# Altium schematic sheet Э3("All Documents",Physical)
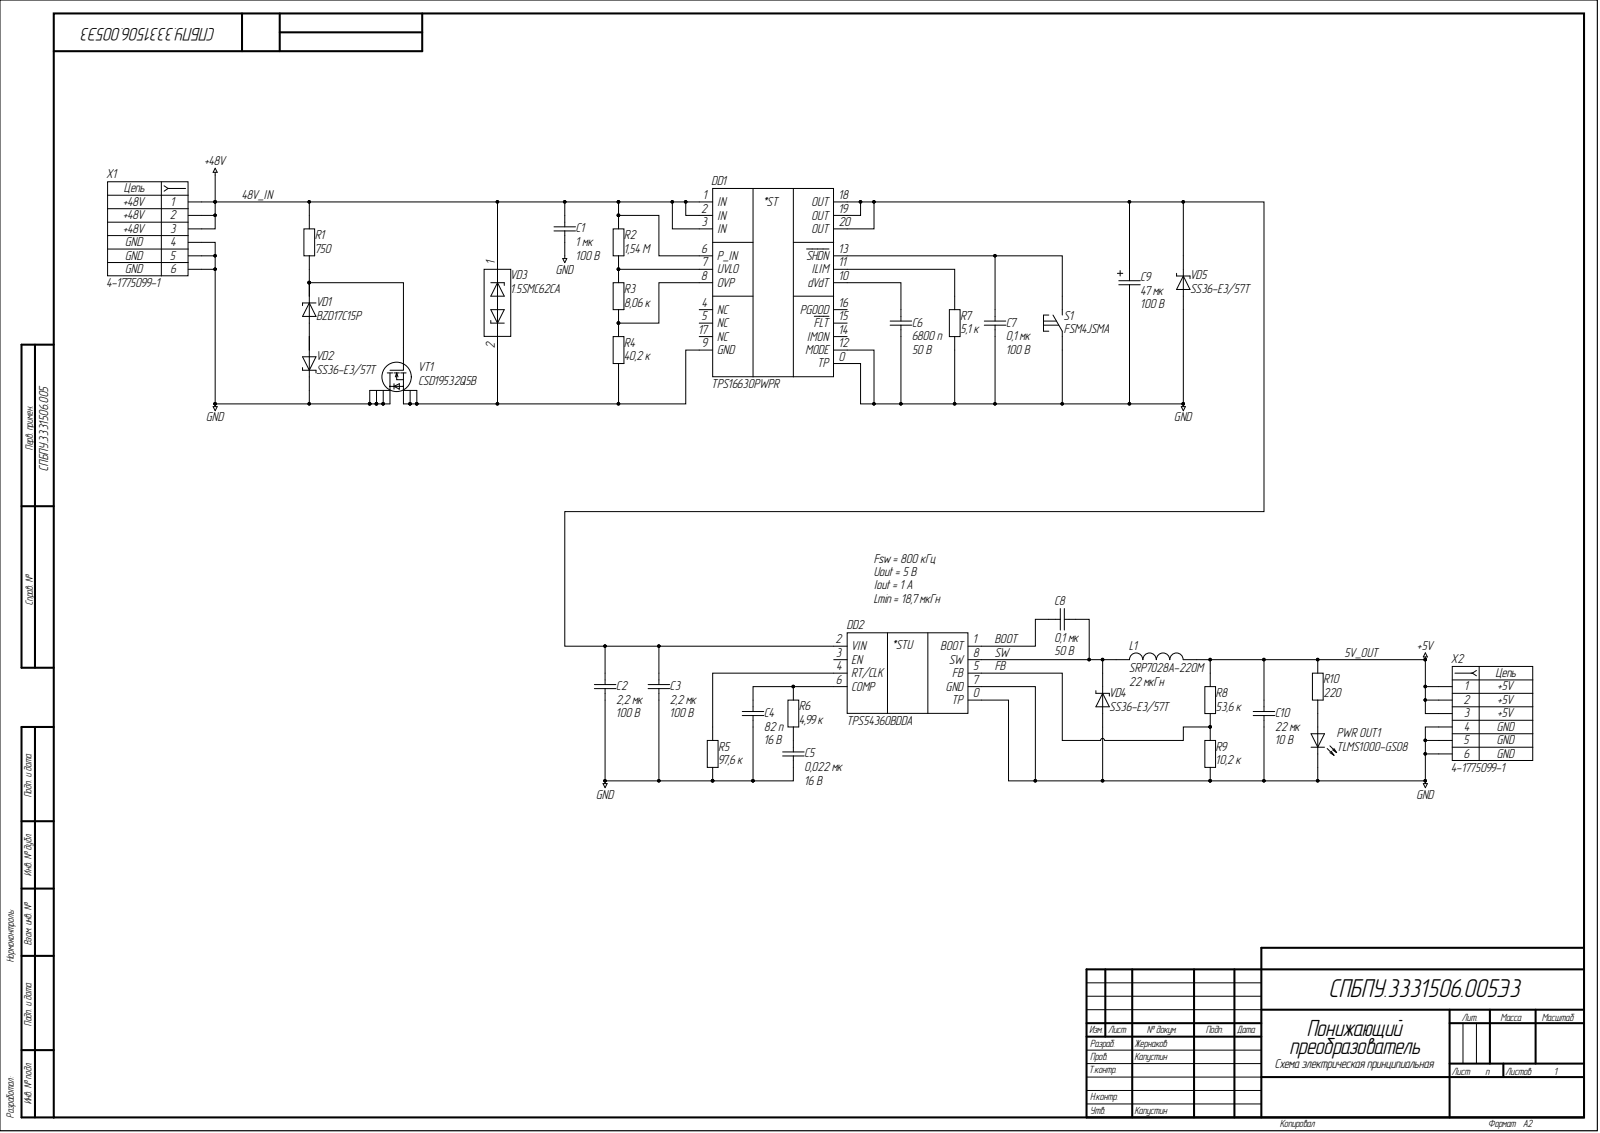
[diagram p0: Э3("All Documents",Physical)]

## Parts
C1  1 мк  [C1206]
C2  2,2 мк  [C1206]
C3  2,2 мк  Номинальное напряжение: 100 В  [C1206]
C4  82 п  [C0603]
C5  0,022 мк  Номинальное напряжение: 16 В  [C0603]
C6  6800 п  Номинальное напряжение: 50 В  [C0402]
C7  0,1 мк  [C0603]
C8  0,1 мк  Номинальное напряжение: 50 В  [C0603]
C9  47 мк  [CAPAE-1050X1050X1250]
C10  22 мк  Номинальное напряжение: 10 В  [C0603]
DD1  TPS16630PWPR  [HTSSOP-20]
DD2  TPS54360BDDA  [HSOIC-8]
L1  SRP7028A-220M  [SRP-2-670X660X280]
PWR_OUT1  TLMS1000-GS08  [HL0603]
R1  750  [R0603]
R2  1,54 М  [R0603]
R3  8,06 к  [R0603]
R4  40,2 к  [R0603]
R5  97,6 к  [R0603]
R6  4,99 к  [R0603]
R7  5,1 к  [R0603]
R8  53,6 к  [R0603]
R9  10,2 к  [R0603]
R10  220  [R0603]
S1  FSM4JSMA  [FSM4JSMA]
VD1  BZD17C15P  [DO-219AB]
VD2  SS36-E3/57T  [DO-214AB]
VD3  1.5SMC62CA  [DO-214AB]
VD4  SS36-E3/57T  [DO-214AB]
VD5  SS36-E3/57T  [DO-214AB]
VT1  CSD19532Q5B  [SON-8]
X1  4-1775099-1  Contact_6: GND  Contact_3: +48V  [4-1775099-1]
X2  4-1775099-1  Contact_6: GND  Contact_3: +5V  [4-1775099-1]

## Nets
GND: C1.2 C2.2 C3.2 C4.2 C5.2 C6.2 C7.2 C9.2 C10.2 DD1.0(TP) DD1.12(MODE) DD2.0(TP) DD2.7(GND) PWR_OUT1.1 R5.1 R7.2 R9.1 S1.1 VD2.1 VD4.2 VD5.2 VT1.D X1.4 X1.5 X1.6 X2.4 X2.5 X2.6
5V_OUT: C10.1 L1.2 R8.2 R10.2 X2.1 X2.2 X2.3
48V_IN: C1.1 DD1.1(IN) DD1.2(IN) DD1.3(IN) DD1.6(P_IN) R1.2 R2.2 VD3.1 X1.1 X1.2 X1.3
BOOT: C8.2 DD2.1(BOOT)
FB: DD2.5(FB) R8.1 R9.2
SW: C8.1 DD2.8(SW) L1.1 VD4.1
NetC2_1: C2.1 C3.1 C9.1 DD1.18(OUT) DD1.19(OUT) DD1.20(OUT) DD2.2(VIN) VD5.1
NetC4_1: C4.1 DD2.6(COMP) R6.2
NetC5_1: C5.1 R6.1
NetC6_1: C6.1 DD1.10(dVdT)
NetC7_1: C7.1 DD1.13(SHDN) S1.2
NetDD1_4: DD1.4(NC)
NetDD1_5: DD1.5(NC)
NetDD1_7: DD1.7(UVLO) R2.1 R3.2
NetDD1_8: DD1.8(OVP) R3.1 R4.2
NetDD1_9: DD1.9(GND) R4.1 VD3.2 VT1.S
NetDD1_11: DD1.11(ILIM) R7.1
NetDD1_14: DD1.14(IMON)
NetDD1_15: DD1.15(FLT)
NetDD1_16: DD1.16(PGOOD)
NetDD1_17: DD1.17(NC)
NetDD2_3: DD2.3(EN)
NetDD2_4: DD2.4(RT/CLK) R5.2
NetPWR OUT1_2: PWR_OUT1.2 R10.1
NetR1_1: R1.1 VD1.1 VT1.G
NetVD1_2: VD1.2 VD2.2

## Library data of the parts
C1 = c0058: =Описание; Фамилия: Ларионов; ТКЕ: X7R; Рабочие температуры: от –55 °С до +125 °С; Производитель: YAGEO Corp.; Отклонение: ±10 %; Описание: Конденсатор чип 1206 1 мкФ 100 В ±10 %; Номинальное напряжение: 100 В; Наименование: CC1206KKX7R0BB105; Корпус: 1206; Database Table Name: Конденсаторы$; Номинал: 1 мк; Напряжение: 100 В; ID: c0058; HelpURL: https://www.yageo.com/upload/media/product/productsearch/datasheet/mlcc/UPY-NP0X7R_MV_100-to-630V_22.pdf; Description: Конденсатор чип 1206 1 мкФ 100 В ±10 %; Component Kind: Standard; Library Name: Database_Students.DbLib
C2 = c0068: =Описание; Фамилия: Ларионов; ТКЕ: X7R; Рабочие температуры: от –55 °С до +125 °С; Производитель: YAGEO Corp.; Отклонение: ±10 %; Описание: Конденсатор чип 1206 2,2 мкФ 100 В ±10 %; Номинальное напряжение: 100 В; Наименование: CC1206KKX7R0BB225; Корпус: 1206; Database Table Name: Конденсаторы$; Номинал: 2,2 мк; Напряжение: 100 В; ID: c0068; HelpURL: https://www.yageo.com/upload/media/product/productsearch/datasheet/mlcc/UPY-NP0X7R_MV_100-to-630V_22.pdf; Description: Конденсатор чип 1206 2,2 мкФ 100 В ±10 %; Component Kind: Standard; Library Name: Database_Students.DbLib
C3 = c0068: =Описание; Фамилия: Ларионов; ТКЕ: X7R; Рабочие температуры: от –55 °С до +125 °С; Производитель: YAGEO Corp.; Отклонение: ±10 %; Описание: Конденсатор чип 1206 2,2 мкФ 100 В ±10 %; Наименование: CC1206KKX7R0BB225; Корпус: 1206; Database Table Name: Конденсаторы$; Номинал: 2,2 мк; Напряжение: 100 В; ID: c0068; HelpURL: https://www.yageo.com/upload/media/product/productsearch/datasheet/mlcc/UPY-NP0X7R_MV_100-to-630V_22.pdf; Description: Конденсатор чип 1206 2,2 мкФ 100 В ±10 %; Component Kind: Standard; Library Name: Database_Students.DbLib
C4 = c0011: =Описание; Фамилия: Ларионов; ТКЕ: C0G; Рабочие температуры: от –55 °С до +125 °С; Производитель: YAGEO Corp.; Отклонение: ±5 %; Описание: Конденсатор чип 0603 82 пФ 16 В ±5 %; Номинальное напряжение: 16 В; Наименование: CC0603JRNPO7BN820; Корпус: 0603; Database Table Name: Конденсаторы$; Номинал: 82 п; Напряжение: 16 В; ID: c0011; HelpURL: https://www.yageo.com/upload/media/product/productsearch/datasheet/mlcc/UPY-GP_NP0_16V-to-50V_18.pdf; Description: Конденсатор чип 0603 82 пФ 16 В ±5 %; Component Kind: Standard; Library Name: Database_Students.DbLib
C5 = c0013: =Описание; Фамилия: Жернаков; Рабочие температуры: от -55 °С до +125 °С; Производитель: YAGEO Corp.; Отклонение: ±10 %; Описание: Конденсатор чип 0603 0,022 мкФ 16 В ±10 %; Наименование: CC0201KRX5R7BB223; Корпус: 0603; Database Table Name: Конденсаторы$; Номинал: 0,022 мк; Напряжение: 16 В; ID: c0013; HelpURL: https://www.yageo.com/upload/media/product/productsearch/datasheet/mlcc/UPY-GPHC_X5R_4V-to-50V_26.pdf; Description: Конденсатор чип 0603 0,022 мкФ 16 В ±10 %; Component Kind: Standard; Library Name: Database_Students.DbLib
C6 = c0005: =Описание; Фамилия: Жернаков; ТКЕ: C0G; Рабочие температуры: от –55 °С до +125 °С; Производитель: Samsung electro-mechanics; Отклонение: ±5 %; Описание: Конденсатор чип 0402 6800 пФ 50 В ±5 %; Наименование: CL05B682JB5NNNC; Корпус: 0402; Database Table Name: Конденсаторы$; Номинал: 6800 п; Напряжение: 50 В; ID: c0005; HelpURL: http://product.samsungsem.com/mlcc/CL05B682JB5NNN.do; Description: Конденсатор чип 0402 6800 пФ 50 В ±5 %; Component Kind: Standard; Library Name: Database_Students.DbLib
C7 = c0028: =Описание; Фамилия: Ларионов; ТКЕ: X7R; Рабочие температуры: от –55 °С до +125 °С; Производитель: YAGEO Corp.; Отклонение: ±10 %; Описание: Конденсатор чип 0603 0,1 мкФ 100 В ±10 %; Номинальное напряжение: 100 В; Наименование: CC0603KRX7R0BB104; Корпус: 0603; Database Table Name: Конденсаторы$; Номинал: 0,1 мк; Напряжение: 100 В; ID: c0028; HelpURL: https://www.yageo.com/upload/media/product/productsearch/datasheet/mlcc/UPY-NP0X7R_MV_100-to-630V_22.pdf; Description: Конденсатор чип 0603 0,1 мкФ 100 В ±10 %; Component Kind: Standard; Library Name: Database_Students.DbLib
C8 = c0027: =Описание; Фамилия: Паньков; ТКЕ: X7R; Рабочие температуры: от –55 °С до +125 °С; Производитель: YAGEO Corp.; Отклонение: ±10 %; Описание: Конденсатор чип 0603 0,1 мкФ 50 В ±10 %; Наименование: CC0603KRX7R9BB104; Корпус: 0603; Database Table Name: Конденсаторы$; Номинал: 0,1 мк; Напряжение: 50 В; ID: c0027; HelpURL: https://www.yageo.com/upload/media/product/products/datasheet/mlcc/UPY-GPHC_X7R_6.3V-to-50V_20.pdf; Description: Конденсатор чип 0603 0,1 мкФ 50 В ±10 %; Component Kind: Standard; Library Name: Database_Students.DbLib
C9 = c0108: =Описание; Фамилия: Ларионов; ТКЕ: X7R; Рабочие температуры: от –55 °С до +125 °С; Производитель: Vishay Intertechnology, Inc.; Отклонение: ±20 %; Описание: Конденсатор электролитический 47 мкФ 100 В ±20 %; Номинальное напряжение: 100 В; Наименование: MAL214699904E3; Корпус: CAPAE (10,5 x 10,5 x 12,5); Database Table Name: Конденсаторы$; Номинал: 47 мк; Напряжение: 100 В; ID: c0108; HelpURL: https://www.vishay.com/capacitors/list/product-28403/; Description: Конденсатор электролитический 47 мкФ 100 В ±20 %; Component Kind: Standard; Library Name: Database_Students.DbLib
C10 = c0026: =Описание; Фамилия: Чернов; Рабочие температуры: от -55 °С до +85 °С; Производитель: YAGEO Corp.; Отклонение: ±20 %; Описание: Конденсатор чип 0603 22 мкФ 10 В ±20 %; Наименование: CC0603MPX5R6BB226; Корпус: 0603; Database Table Name: Конденсаторы$; Номинал: 22 мк; Напряжение: 10 В; ID: c0026; HelpURL: https://www.yageo.com/upload/media/product/productsearch/datasheet/mlcc/UPY-GPHC_X5R_4V-to-50V_26.pdf; Description: Конденсатор чип 0603 22 мкФ 10 В ±20 %; Component Kind: Standard; Library Name: Database_Students.DbLib
DD1 = dd0002: =Описание; Фамилия: Жернаков; Ток: от 0,6 А до 6 А; Рабочие температуры: от -40 °С до +125 °С; Производитель: Texas Instruments; Описание: Защитная микросхема eFuse 60 В и 6 А; Напряжение: от 4,5 В до 60 В; Максимальное напряжение: 67 В; Database Table Name: Защитные микросхемы$; Наименование: TPS16630PWPR; Корпус: HTSSOP-20; ID: dd0002; HelpURL: https://www.ti.com/product/TPS1663; Description: Защитная микросхема eFuse 60 В и 6 А; Component Kind: Standard; Library Name: Database_Students.DbLib
DD2 = dd0002: =Описание; Частота переключения: от 100 кГц до 2,5 МГц; Фамилия: Жернаков; Рабочие температуры: от -40 °С до +150 °С; Производитель: Texas Instruments; Описание: Понижающий преобразователь напряжения 60 В и 3,5 А; Коммутируемый ток: от 0 А до 3,5 А; Выходное напряжение: от 1 В до 58,8 В; Входное напряжение: от 4,5 В до 60 В; Database Table Name: Преобразователи напряжения$; Наименование: TPS54360BDDA; Корпус: HSOIC-8; ID: dd0002; HelpURL: https://www.ti.com/product/TPS54360B#product-details##params; Description: Понижающий преобразователь напряжения 60 В и 3,5 А; Component Kind: Standard; Library Name: Database_Students.DbLib
L1 = l0004: =Описание; Фамилия: Ларионов; Ток насыщения: 3,5 А; Резонансная частота: 10 МГц; Рабочие температуры: от –55 °С до +150 °С; Рабочее напряжение: не указано; Производитель: Bourns, Inc.; Отклонение: ±20 %; Описание: Катушка индуктивности 22 мкГн ±20 %; Номинальный ток (при нагреве на 20 °С/40 °С): 2 А; Номинальное активное сопротивление: 165 мОм; Номинал: 22 мкГн; Максимальное активное сопротивление: 190 мОм; Корпус: 6,7 x 6,6 x 2,8; Database Table Name: Катушки индуктивности$; Наименование: SRP7028A-220M; Индуктивность: 22 мкГн; ID: l0004; HelpURL: https://www.bourns.com/products/magnetic-products/power-inductors-aec-q200-compliant/product/SRP7028A; Description: Катушка индуктивности 22 мкГн ±20 %; Component Kind: Standard; Library Name: Database_Students.DbLib
PWR_OUT1 = hl0001: =Описание; Цвет: Red; Фамилия: Ларионов; Рассеиваемая мощность: 40 мВт; Рабочие температуры: от -40 °С до +100 °С; Производитель: Vishay Intertechnology, Inc.; Описание: Светодиод чип 0603 красный; Номинальный прямой ток: 15 мА; Номинальное падение напряжения: 1,8 В; Номинальная сила света: 4 мкд; Номинальная длина волны: 628 нм; Корпус: 0603; Database Table Name: Светодиоды$; Наименование: TLMS1000-GS08; ID: hl0001; HelpURL: https://www.vishay.com/leds/list/product-83172/; Description: Светодиод чип 0603 красный; Component Kind: Standard; Library Name: Database_Students.DbLib
R1 = r0028: =Описание; Фамилия: Паньков; Рабочие температуры: от –55 °С до +155 °С; Производитель: YAGEO Corp.; Отклонение: ±1 %; Описание: Резистор чип 0603 750 Ом ±1 % 0,1 Вт; Номинальный ток: 1 А; Номинальное напряжение: 75 В; Наименование: RC0603FR-07750RL; Корпус: 0603; Database Table Name: Резисторы$; Номинал: 750; ID: r0028; HelpURL: https://www.yageo.com/upload/media/product/productsearch/datasheet/rchip/PYu-RC_Group_51_RoHS_L_11.pdf; Description: Резистор чип 0603 750 Ом ±1 % 0,1 Вт; Component Kind: Standard; Library Name: Database_Students.DbLib
R2 = r0042: =Описание; Фамилия: Жернаков; Рабочие температуры: от -55 °С до +155 °С; Производитель: YAGEO Corp.; Отклонение: ±1 %; Описание: Резистор чип 0603 1,54 МОм ±1 % 0,1 Вт; Номинальный ток: 1 А; Номинальное напряжение: 75 В; Наименование: RC0603FR-071M54L; Корпус: 0603; Database Table Name: Резисторы$; Номинал: 1,54 М; ID: r0042; HelpURL: https://www.yageo.com/upload/media/product/productsearch/datasheet/rchip/PYu-RC_Group_51_RoHS_L_11.pdf; Description: Резистор чип 0603 1,54 МОм ±1 % 0,1 Вт; Component Kind: Standard; Library Name: Database_Students.DbLib
R3 = r0020: =Описание; Фамилия: Жернаков; Рабочие температуры: от -55 °С до +155 °С; Производитель: YAGEO Corp.; Отклонение: ±1 %; Описание: Резистор чип 0603 8,06 кОм ±1 % 0,1 Вт; Номинальный ток: 1 А; Номинальное напряжение: 75 В; Наименование: RC0603FR-078K06L; Корпус: 0603; Database Table Name: Резисторы$; Номинал: 8,06 к; ID: r0020; HelpURL: https://www.yageo.com/upload/media/product/productsearch/datasheet/rchip/PYu-RC_Group_51_RoHS_L_11.pdf; Description: Резистор чип 0603 8,06 кОм ±1 % 0,1 Вт; Component Kind: Standard; Library Name: Database_Students.DbLib
R4 = r0028: =Описание; Фамилия: Жернаков; Рабочие температуры: от -55 °С до +155 °С; Производитель: YAGEO Corp.; Отклонение: ±1 %; Описание: Резистор чип 0603 40,2 кОм ±1 % 0,1 Вт; Номинальный ток: 1 А; Номинальное напряжение: 75 В; Наименование: RC0603FR-0740K2L; Корпус: 0603; Database Table Name: Резисторы$; Номинал: 40,2 к; ID: r0028; HelpURL: https://www.yageo.com/upload/media/product/productsearch/datasheet/rchip/PYu-RC_Group_51_RoHS_L_11.pdf; Description: Резистор чип 0603 40,2 кОм ±1 % 0,1 Вт; Component Kind: Standard; Library Name: Database_Students.DbLib
R5 = r0029: =Описание; Фамилия: Водорезов; Рабочие температуры: от -55 °С до +155 °С; Производитель: YAGEO Corp.; Отклонение: ±1 %; Описание: Резистор чип 0603 97,6 кОм ±1 % 0,1 Вт; Номинальный ток: 1 А; Номинальное напряжение: 75 В; Наименование: RC0603FR-0797K6L; Корпус: 0603; Database Table Name: Резисторы$; Номинал: 97,6 к; ID: r0029; HelpURL: https://www.yageo.com/upload/media/product/productsearch/datasheet/rchip/PYu-RC_Group_51_RoHS_L_11.pdf; Description: Резистор чип 0603 97,6 кОм ±1 % 0,1 Вт; Component Kind: Standard; Library Name: Database_Students.DbLib
R6 = r0017: =Описание; Фамилия: Жернаков; Рабочие температуры: от -55 °С до +155 °С; Производитель: YAGEO Corp.; Отклонение: ±1 %; Описание: Резистор чип 0603 4,99 кОм ±1 % 0,1 Вт; Номинальный ток: 1 А; Номинальное напряжение: 75 В; Наименование: RC0603FR-074K99L; Корпус: 0603; Database Table Name: Резисторы$; Номинал: 4,99 к; ID: r0017; HelpURL: https://www.yageo.com/upload/media/product/productsearch/datasheet/rchip/PYu-RC_Group_51_RoHS_L_11.pdf; Description: Резистор чип 0603 4,99 кОм ±1 % 0,1 Вт; Component Kind: Standard; Library Name: Database_Students.DbLib
R7 = r0019: =Описание; Фамилия: Жернаков; Рабочие температуры: от -55 °С до +155 °С; Производитель: YAGEO Corp.; Отклонение: ±1 %; Описание: Резистор чип 0603 5,1 кОм ±1 % 0,1 Вт; Номинальный ток: 1 А; Номинальное напряжение: 75 В; Наименование: RC0603FR-075K1L; Корпус: 0603; Database Table Name: Резисторы$; Номинал: 5,1 к; ID: r0019; HelpURL: https://www.yageo.com/upload/media/product/productsearch/datasheet/rchip/PYu-RC_Group_51_RoHS_L_11.pdf; Description: Резистор чип 0603 5,1 кОм ±1 % 0,1 Вт; Component Kind: Standard; Library Name: Database_Students.DbLib
R8 = r0017: =Описание; Фамилия: Жернаков; Рабочие температуры: от -55 °С до +155 °С; Производитель: YAGEO Corp.; Отклонение: ±0,1 %; Описание: Резистор чип 0603 53,6 кОм ±0,1 % 0,1 Вт; Номинальный ток: 1 А; Номинальное напряжение: 75 В; Наименование: RC0603BR-0753K6L; Корпус: 0603; Database Table Name: Резисторы$; Номинал: 53,6 к; ID: r0017; HelpURL: https://www.yageo.com/upload/media/product/productsearch/datasheet/rchip/PYu-RC_Group_51_RoHS_L_11.pdf; Description: Резистор чип 0603 53,6 кОм ±0,1 % 0,1 Вт; Component Kind: Standard; Library Name: Database_Students.DbLib
R9 = r0014: =Описание; Фамилия: Жернаков; Рабочие температуры: от -55 °С до +155 °С; Производитель: YAGEO Corp.; Отклонение: ±1 %; Описание: Резистор чип 0603 10,2 кОм ±1 % 0,1 Вт; Номинальный ток: 1 А; Номинальное напряжение: 75 В; Наименование: RC0603FR-0710K2L; Корпус: 0603; Database Table Name: Резисторы$; Номинал: 10,2 к; ID: r0014; HelpURL: https://www.yageo.com/upload/media/product/productsearch/datasheet/rchip/PYu-RC_Group_51_RoHS_L_11.pdf; Description: Резистор чип 0603 10,2 кОм ±1 % 0,1 Вт; Component Kind: Standard; Library Name: Database_Students.DbLib
R10 = r0009: =Описание; Фамилия: Ларионов; Рабочие температуры: от -55 °С до +155 °С; Производитель: YAGEO Corp.; Отклонение: ±1 %; Описание: Резистор чип 0603 220 Ом ±1 % 0,1 Вт; Номинальный ток: 1 А; Номинальное напряжение: 75 В; Наименование: RC0603FR-07220RL; Корпус: 0603; Database Table Name: Резисторы$; Номинал: 220; ID: r0009; HelpURL: https://www.yageo.com/upload/media/product/productsearch/datasheet/rchip/PYu-RC_Group_51_RoHS_L_11.pdf; Description: Резистор чип 0603 220 Ом ±1 % 0,1 Вт; Component Kind: Standard; Library Name: Database_Students.DbLib
S1 = sw0001: =Описание; Фамилия: Паньков; Ток нагрузки: 50 мА @ 24 В; Сопротивление контакта: 100 мОм; Рабочие температуры: от –35 °С до +85 °С; Промывка: Разрешена; Производитель: TE Connectivity; Описание: Тактовая кнопка поверхностного монтажа; Корпус: 6,00x6,00x4,98; Количество контактов: 4; Database Table Name: Переключатели$; Наименование: FSM4JSMA; ID: sw0001; HelpURL: https://www.te.com/usa-en/product-4-1437565-1.html; Description: Тактовая кнопка поверхностного монтажа; Component Kind: Standard; Library Name: Database_Students.DbLib
VD1 = vd0016: =Описание; Фамилия: Жернаков; Разброс напряжения стабилизации: 13,8...15,6 В; Рабочие температуры: от –55 °С до +150 °С; Производитель: Vishay Intertechnology, Inc.; Описание: Стабилитрон 15 В; Номинальный ток стабилизации: 50 мА; Номинальное напряжение стабилизации: 15 В; Database Table Name: Стабилитроны$; Наименование: BZD17C15P; Корпус: DO-219AB; ID: vd0016; HelpURL: https://www.vishay.com/product?docid=85161; Description: Стабилитрон 15 В; Component Kind: Standard; Library Name: Database_Students.DbLib
VD2 VD5 = vd0003: =Описание; Фамилия: Жернаков; Рабочие температуры: от -55 °С до +150 °С; Производитель: Vishay Intertechnology, Inc.; Пиковое прямое напряжение: 0,75 В; Описание: Диод Шоттки 60 В 3 А; Макс допустимый средний прямой ток: 3 А; Импульсное обратное напряжение: 60 В; Database Table Name: Диоды$; Наименование: SS36-E3/57T; Корпус: DO-214AB; ID: vd0003; HelpURL: https://www.vishay.com/product?docid=88751; Description: Диод Шоттки 60 В 3 А; Component Kind: Standard; Library Name: Database_Students.DbLib
VD3 = vd0004: =Описание; Фамилия: Ларионов; Производитель: Littelfuse, Inc.; Описание: Двунаправленная диодная сборка для подавления помех, 53 В; Database Table Name: Диодные сборки$; Наименование: 1.5SMC62CA; Корпус: DO-214AB; ID: vd0004; HelpURL: https://www.littelfuse.com/products/tvs-diodes/surface-mount/1_5smc.aspx; Description: Двунаправленная диодная сборка для подавления помех, 53 В; Component Kind: Standard; Library Name: Database_Students.DbLib
VD4 = vd0003: =Описание; Фамилия: Жернаков; Рабочие температуры: от –55 °С до +150 °С; Производитель: Vishay Intertechnology, Inc.; Пиковое прямое напряжение: 0,75 В; Описание: Диод Шоттки 60 В 3 А; Макс допустимый средний прямой ток: 3 А; Импульсное обратное напряжение: 60 В; Database Table Name: Диоды$; Наименование: SS36-E3/57T; Корпус: DO-214AB; ID: vd0003; HelpURL: https://www.vishay.com/product?docid=88751; Description: Диод Шоттки 60 В 3 А; Component Kind: Standard; Library Name: Database_Students.DbLib
VT1 = vt0001: =Описание; Фамилия: Жернаков; Ток стока: 17 А; Сопротивление сток-исток: 0,0057 Ом; Рабочие температуры: от -55 °С до +150 °С; Производитель: Texas Instruments; Описание: Транзистор полевой N-канальный 100 В 17 А; Напряжение сток-исток: 100 В; Напряжение затвор-исток: ±20 В; Database Table Name: Транзисторы$; Наименование: CSD19532Q5B; Корпус: SON-8; ID: vt0001; HelpURL: https://www.ti.com/product/CSD19532Q5B?keyMatch=CSD19532Q5B&tisearch=Search-EN-everything&usecase=OPN; Description: Транзистор полевой N-канальный 100 В 17 А; Component Kind: Standard; Library Name: Database_Students.DbLib
X1 X2 = x0005: =Описание; Фамилия: Жернаков; Производитель: TE Connectivity; Описание: ATX Power 6 pin гнездо прямой; Database Table Name: Разъемы$; Наименование: 4-1775099-1; ID: x0005; HelpURL: https://www.te.com/usa-en/product-4-1775099-1.html?q=ATX%2BPower&source=header; Description: ATX Power 6 pin гнездо прямой; Contact_5: GND; Contact_4: GND; Component Kind: Standard; Library Name: Database_Students.DbLib
  X1: Contact_2: +48V; Contact_1: +48V
  X2: Contact_2: +5V; Contact_1: +5V
